# Altium schematic sheet Power_Supplies.SchDoc(Power_Supplies)
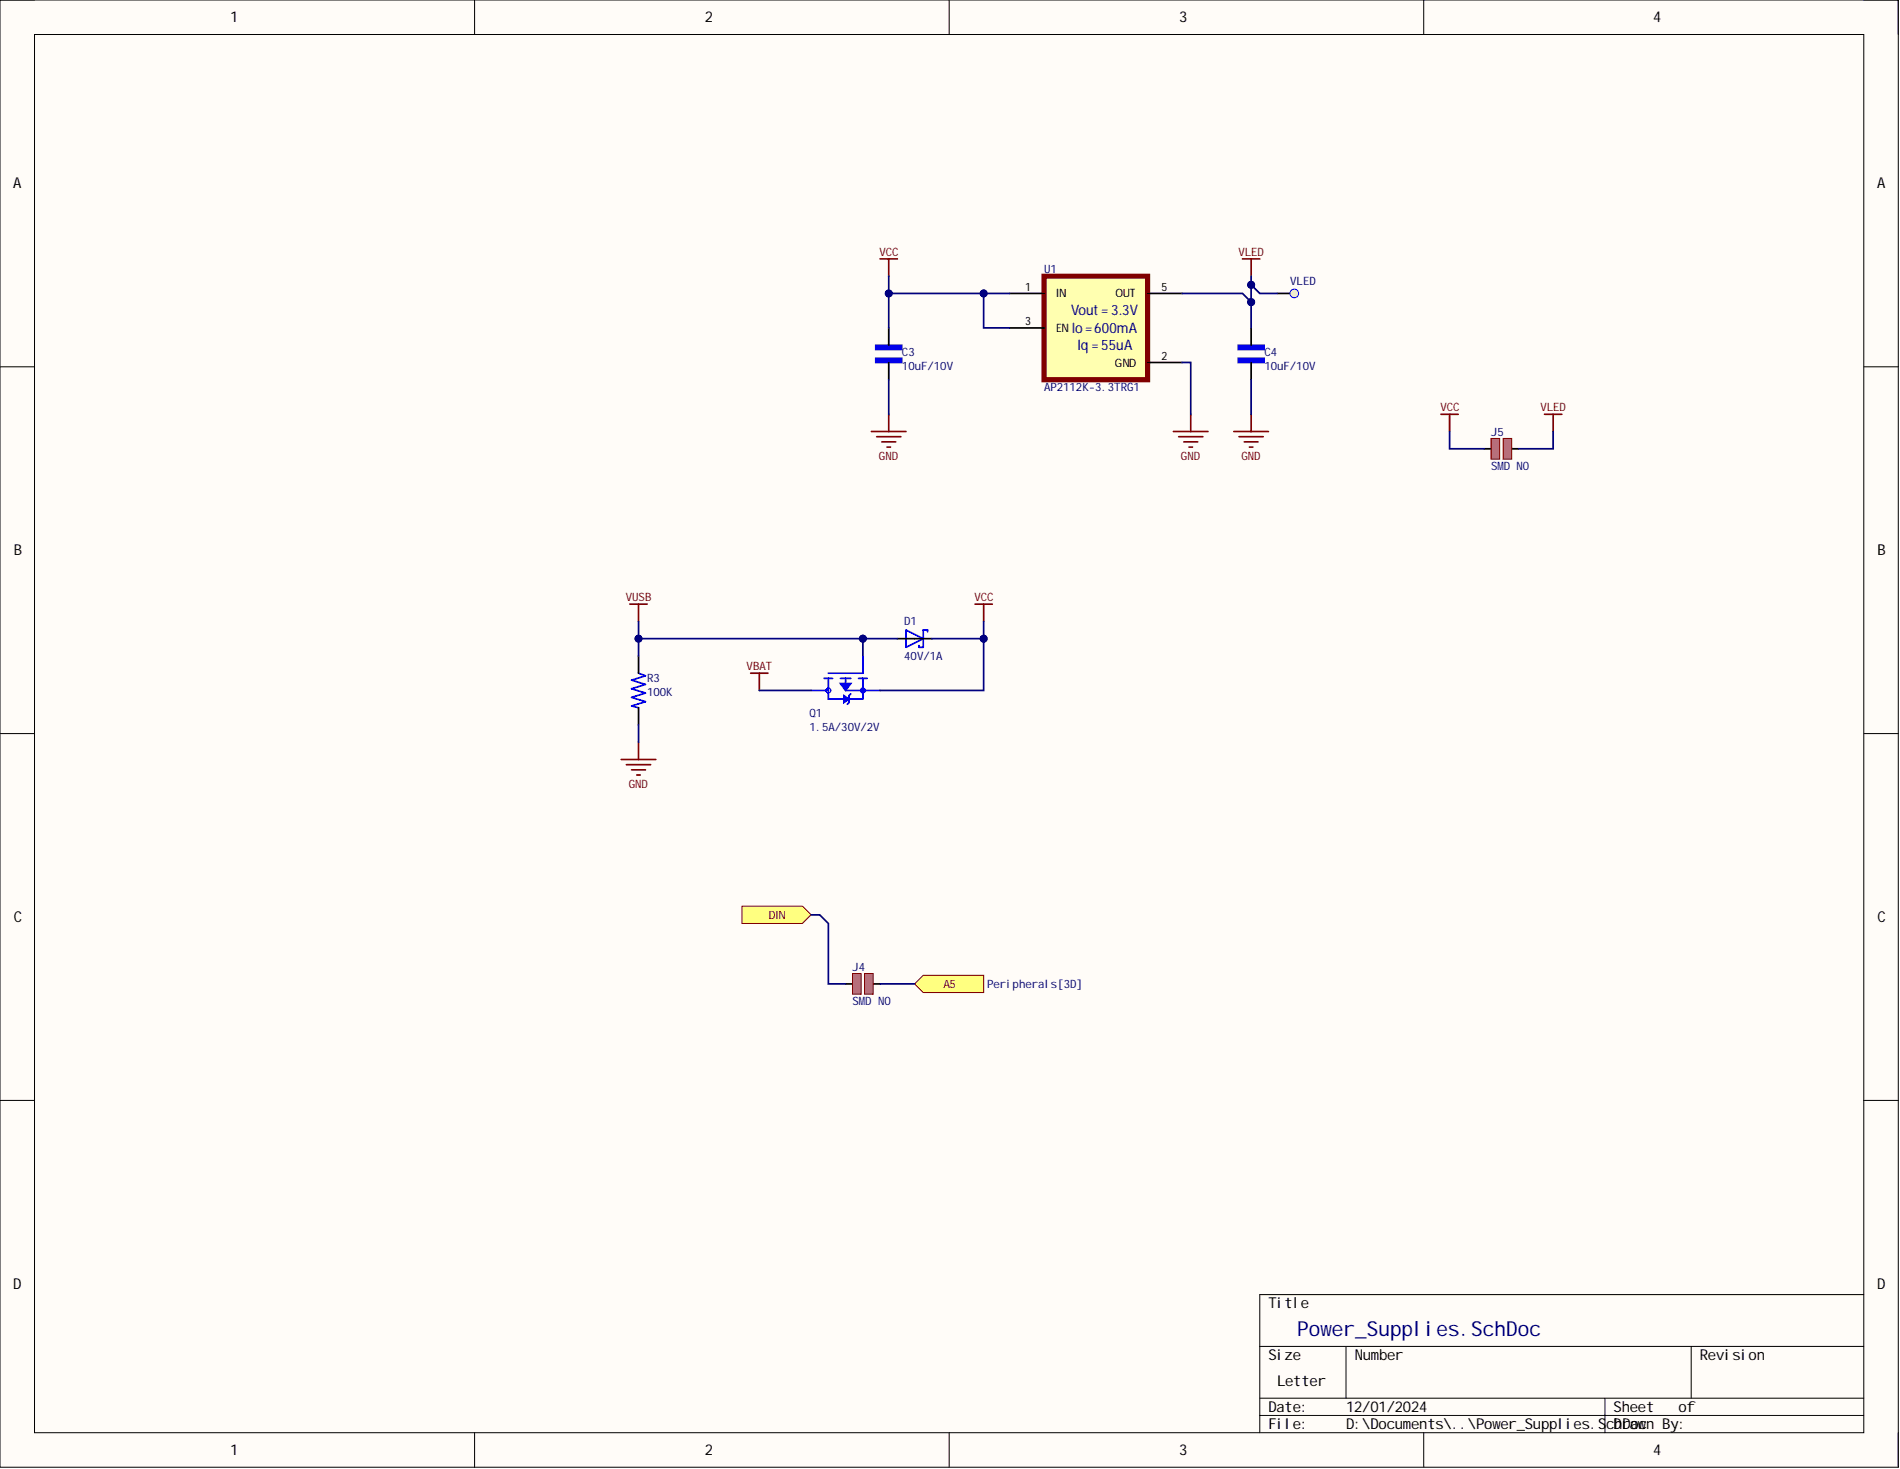
[diagram p0: Power_Supplies.SchDoc]

## Parts
C3  10uF/10V  [C0603]
C4  10uF/10V  [C0603]
D1  40V/1A  [SMA-2]
J4  SMD NO  [JUMPER_SMD_NO]
J5  SMD NO  [JUMPER_SMD_NO]
Q1  1.5A/30V/2V  [SOT-23-3]
R3  100K  [R0603]
U1  AP2112K-3.3TRG1  Max Output Current: 600mA  Output Voltage: 3.3V  Quiescent Current: 55uA  [SOT-25-5]
VLED  Test point 1.2mm  [TEST_POINT_1.2MM]  (value not drawn)  (no BOM)

## Ports
A5, DIN

## Nets
GND: C3.2 C4.2 R3.2 U1.2(GND)
VBAT: Q1.3
VCC: C3.1 D1.K J5.1 Q1.2 U1.1(IN) U1.3(EN)
VLED: C4.1 J5.2 U1.5(OUT) VLED.1
VUSB: D1.A Q1.1 R3.1
A5: J4.2 ; port A5
DIN: J4.1 ; port DIN

## Library data of the parts
C3 C4 = C0603 10uF/10V: MLCC 10uF 10V X5R 20% 0603; Manufacturer: Samsung; Manufacturer Part Number: CL10A106MP8NNND; Category: Ceramic Capacitors; Component Kind: Standard; Library Name: Capacitors.SchLib; Pricing 1: 1=0.20000, 10=0.14000, 50=0.14000, 100=0.06600, 1000=0.03900, 10000=0.03000 (USD); RoHS: Yes; RoHS Compliant: Yes; Stock 1: 58774; Supplier 1: Mouser; Supplier 2: Mouser; Supplier Part Number 1: 187-CL10A106MP8NNND; Supplier Part Number 2: 963-LMK107BBJ106MAHT
D1 = SS14: SS14 Series 500 mV 1 A 40 V Max Reverse Voltage Schottky Rectifier - DO-214AC; Manufacturer: ON Semiconductor / Fairchild; Average Rectified Current: 1A; Forward Voltage: 500mV; Max Forward Surge Current (Ifsm): 40A; Max Repetitive Reverse Voltage (Vrrm): 40V; Max Reverse Current: 200uA; Polarity: Standard; Case/Package: DO-214AC; Category: Schottky Diodes; Component Kind: Standard; ComponentLink1Description: https://datasheet.ciiva.com/4713/ss14-197224-4713938.pdf?src-supplier=Mouser; ComponentLink1URL: https://datasheet.ciiva.com/4713/ss14-197224-4713938.pdf?src-supplier=Mouser; ComponentLink2Description: https://datasheet.ciiva.com/7965/1766303-7965241.pdf?src-supplier=Element14; ComponentLink2URL: https://datasheet.ciiva.com/7965/1766303-7965241.pdf?src-supplier=Element14; ComponentLink3Description: https://datasheet.ciiva.com/22651/1880990-22651980.pdf?src-supplier=Element14; ComponentLink3URL: https://datasheet.ciiva.com/22651/1880990-22651980.pdf?src-supplier=Element14; ComponentLink4Description: https://datasheet.ciiva.com/8303/ss19-8303766.pdf?src-supplier=Avnet; ComponentLink4URL: https://datasheet.ciiva.com/8303/ss19-8303766.pdf?src-supplier=Avnet; ComponentLink5Description: https://datasheet.ciiva.com/910/ss12-910696.pdf?src-supplier=Digikey; ComponentLink5URL: https://datasheet.ciiva.com/910/ss12-910696.pdf?src-supplier=Digikey; ComponentLink6Description: https://datasheet.ciiva.com/910/ss12-910697.pdf?src-supplier=Digikey; ComponentLink6URL: https://datasheet.ciiva.com/910/ss12-910697.pdf?src-supplier=Digikey; ComponentLink7Description: https://datasheet.ciiva.com/910/ss12-910695.pdf?src-supplier=Digikey; ComponentLink7URL: https://datasheet.ciiva.com/910/ss12-910695.pdf?src-supplier=Digikey; Current: 1A; Current Rating: 1A; Forward Current: 1A; Height: 2.2mm; Length: 4.75mm; Library Name: Diodes.SchLib; Manufacturer Part Number: SS14; Max Operating Temperature: 125°C; Max Reverse Voltage (DC): 40V; Min Operating Temperature: -65°C; Mount: Surface Mount; Number of Pins: 2; Output Current: 1A; Package Quantity: 7500; Packaging: Tape and Reel; Peak Non-Repetitive Surge Current: 40A; Pricing 1: 1=0.37, 10=0.261, 50=0.261, 100=0.117, 1000=0.082, 10000=0.075 (USD); Radiation Hardening: No; Reach SVHC Compliant: No; RoHS: Yes; RoHS Compliant: Yes; Series: -; Stock 1: 331320; Supplier 1: Mouser; Supplier Part Number 1: 512-SS14; Termination: SMD/SMT; Voltage: 40V; Voltage Rating (DC): 40V; Weight: 0.003739oz; Width: 2.95mm
J4 J5 = JUMPER_SMD_NO: Rule: Short-Circuit Constraint [Short Circuit - Allowed]; Component Kind: Net Tie; Library Name: Jumpers.SchLib
Q1 = MOSFET P-CH 30V/1.5A BSS314: MOSFET P-Ch -30V -1.5A SOT-23-3; Manufacturer: Infineon; Manufacturer Part Number: BSS314PE; Component Kind: Standard; Halogen Free: Halogen Free; Library Name: DiscreteSemi.SchLib; Number of Pins: 3; Packaging: Tape and Reel; Pricing 1: 1=0.46000, 10=0.34700, 50=0.34700, 100=0.21700, 500=0.14800, 1000=0.11400, 3000=0.10200, 6000=0.09600, 9000=0.09400, 10000=0.09400 (USD); RoHS: Yes; RoHS Compliant: Yes; Stock 1: 2505; Supplier 1: Mouser; Supplier 2: Mouser; Supplier Part Number 1: 726-BSS314PEH6327; Supplier Part Number 2: 726-BSS314PEH6327XTS
R3 = R0603 100K: RES 0603 100K OHM 1% 1/10W; Manufacturer: Yageo; Manufacturer Part Number: RC0603FR-07100KL; Power Rating: 100mW; Resistance: 100kR; Temperature Coefficient: 100ppm/°C; Voltage Rating: 50V; Working Voltage: 75V; Case Code (Imperial): 0603; Case Code (Metric): 1608; Case/Package: 0603; Category: Chip SMD Resistors; Component Kind: Standard; Depth: 0.8mm; Height: 0.45mm; Lead Free: Lead Free; Length: 1.6mm; Library Name: Resistors.SchLib; Max Operating Temperature: 155°C; Military Standard: Not; Min Operating Temperature: -55°C; Number of Pins: 2; Number of Terminations: 2; Packaging: Tape and Reel; Pricing 1: 1=0.10000, 10=0.02100, 100=0.00900, 1000=0.00400, 5000=0.00300, 10000=0.00200 (USD); REACH SVHC: No SVHC; Resistor Type: Thick Film; RoHS: Yes; Stock 1: 4536807; Supplier 1: Mouser; Supplier Part Number 1: 603-RC0603FR-07100KL; Termination: SMD/SMT; Tolerance: 1%; Voltage Rating (DC): 50V; Width: 0.8mm
U1 = AP2112K-3.3TRG1: IC REG LINEAR 3.3V 600MA SOT25; Manufacturer: Diodes; Accuracy: 1.5%; Dropout Voltage: 250mV; Max Input Voltage: 6V; Min Input Voltage: 2.5V; Number of Outputs: 1; Output Type: Fixed; Polarity: Positive; Case/Package: SOT-23-5; Category: Voltage Regulators - Linear; Component Kind: Standard; ComponentLink1Description: https://datasheet.ciiva.com/29569/ap2112-29569917.pdf?src-supplier=Digi-Key; ComponentLink1URL: https://datasheet.ciiva.com/29569/ap2112-29569917.pdf?src-supplier=Digi-Key; ComponentLink2Description: https://datasheet.ciiva.com/29569/ap2112-29569918.pdf?src-supplier=Digi-Key; ComponentLink2URL: https://datasheet.ciiva.com/29569/ap2112-29569918.pdf?src-supplier=Digi-Key; ComponentLink3Description: https://datasheet.ciiva.com/29569/ap2112-29569919.pdf?src-supplier=Digi-Key; ComponentLink3URL: https://datasheet.ciiva.com/29569/ap2112-29569919.pdf?src-supplier=Digi-Key; ComponentLink4Description: https://datasheet.ciiva.com/30016/ap2112-30016792.pdf?src-supplier=Avnet; ComponentLink4URL: https://datasheet.ciiva.com/30016/ap2112-30016792.pdf?src-supplier=Avnet; Height: 1.3mm; Lead Free: Lead Free; Length: 3.1mm; Library Name: IC Power.SchLib; Manufacturer Part Number: AP2112K-3.3TRG1; Max Operating Temperature: 85°C; Min Operating Temperature: -40°C; Mount: Surface Mount; Number of Pins: 5; Number of Regulators: 1; Output Current: 600mA; Package Quantity: 1; Packaging: Tape and Reel; Pricing 1: 1=0.47000, 10=0.31700, 50=0.31700, 100=0.15000, 1000=0.11600, 10000=0.08900 (USD); RoHS: Yes; RoHS Compliant: Yes; Stock 1: 4864; Supplier 1: Mouser; Supplier Part Number 1: 621-AP2112K-3.3TRG1; Width: 1.7mm
VLED = Test point: SMD test point; Library Name: Connectors.SchLib
